# Altium schematic sheet isoSPI_Interface.SchDoc
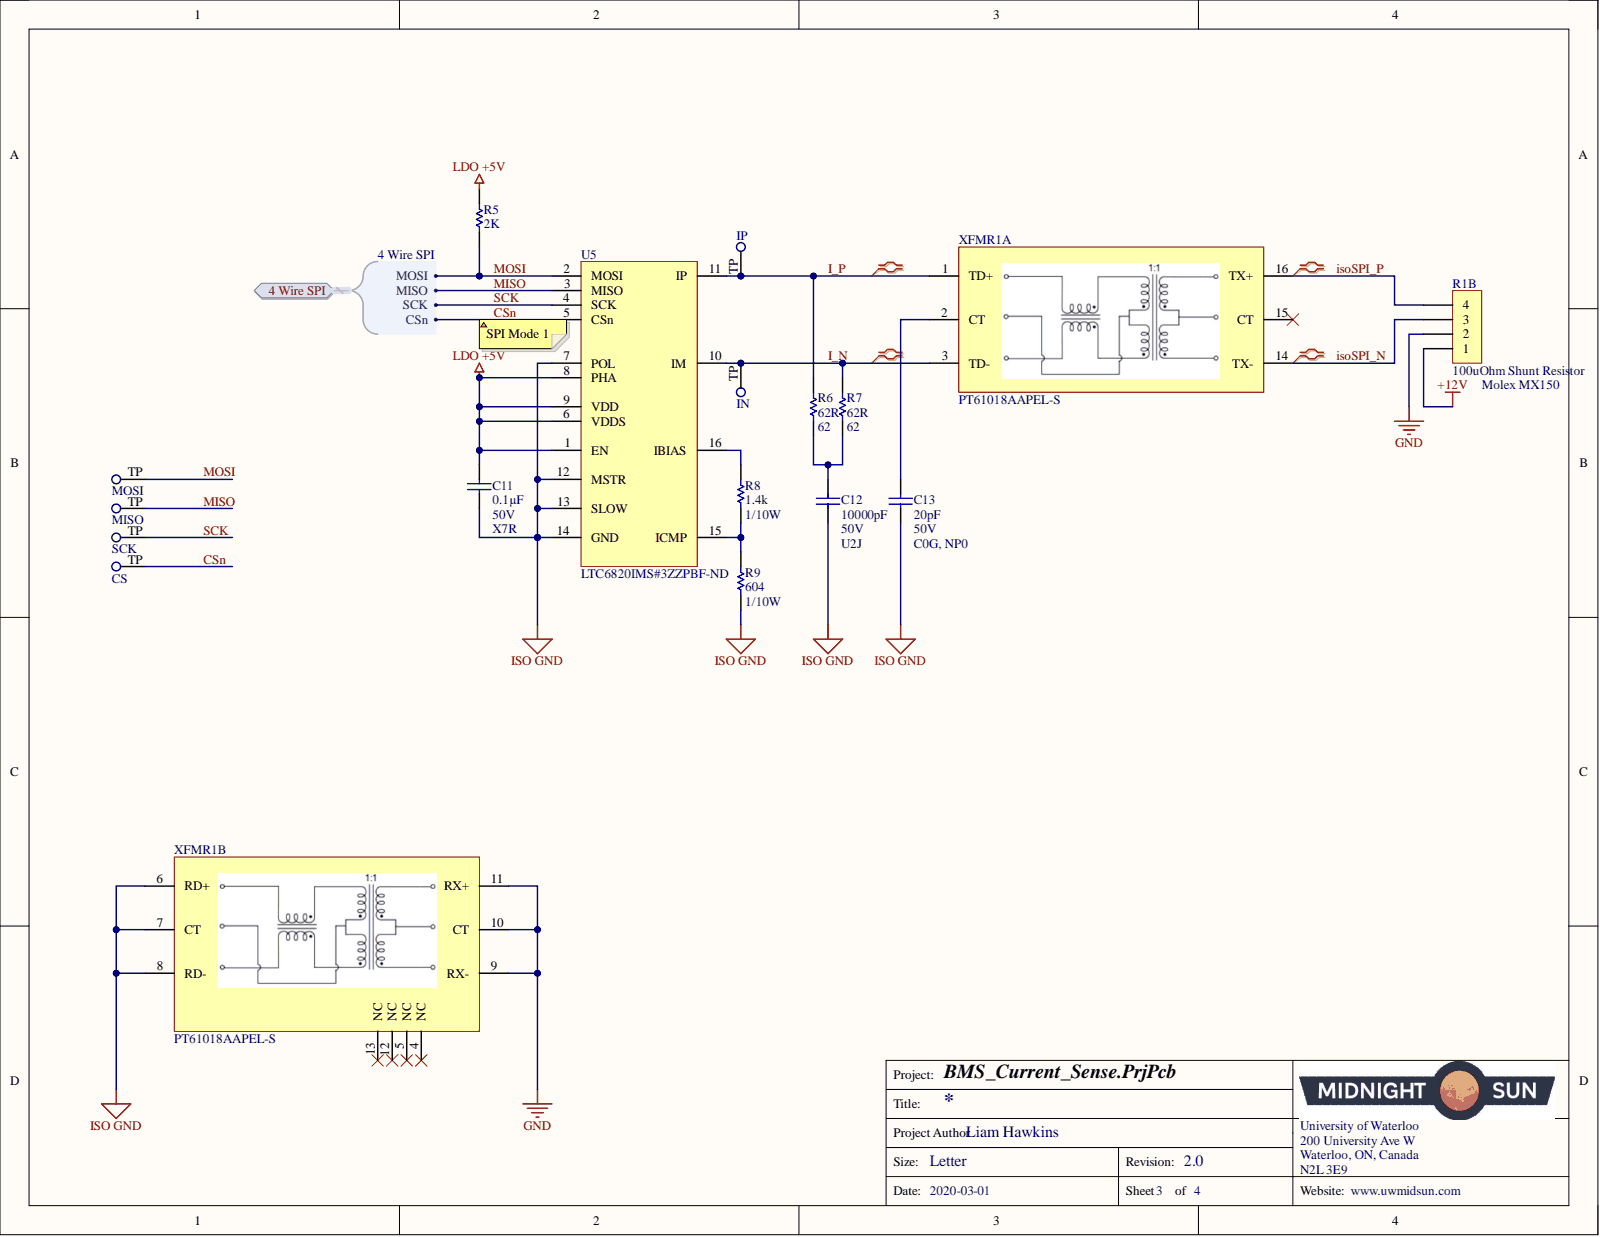
[diagram p0: isoSPI_Interface.SchDoc]

## Parts
C11  Capacitance: 0.1µF  Temperature Coefficient: X7R  Voltage: 50V  [CAP, 0603]  (hidden comment: CAP CER 0.1UF 50V 10% X7R 0603)
C12  Capacitance: 10000pF  Temperature Coefficient: U2J  Voltage: 50V  [CAP, 0603]  (hidden comment: CAP CER 10nF 50V 5% X7R 0603)
C13  Capacitance: 20pF  Voltage: 50V  [CAP, 0603]  (hidden comment: CAP CER 20PF 50V ±5% C0G/NP0 0603)
CS  Test Point  [Test Point]  (value not drawn)  (no BOM)
IN  Test Point  [Test Point]  (value not drawn)  (no BOM)
IP  Test Point  [Test Point]  (value not drawn)  (no BOM)
MISO  Test Point  [Test Point]  (value not drawn)  (no BOM)
MOSI  Test Point  [Test Point]  (value not drawn)  (no BOM)
R1  100uOhm Shunt Resistor  [RES SHUNT 100UOHM 60MM]  (part B)
R5  2K  [RES, 0603]
R6  62R  value 62  [RES, 0603]
R7  62R  value 62  [RES, 0603]
R8  Power: 1/10W  Resistance: 1.4k  [RES, 0603]  (hidden comment: RES 1.4k OHM 1% 1/10W 0603)
R9  Power: 1/10W  [RES, 0603]  (hidden comment: RES 604 OHM 1% 1/10W 0603)
SCK  Test Point  [Test Point]  (value not drawn)  (no BOM)
U5  Supplier Part Number 1: LTC6820IMS#3ZZPBF-ND  [IC isoSPI Interface LTC6820 MSOP]  (hidden comment: IC ISOSPI COMM INTERFACE LTC6820IMS#3ZZPBF)
XFMR1  MFG_PN: PT61018AAPEL-S  [IC, PULSE XFMR 1CT:1CT 350UH SMD]  (hidden comment: IC PULSE XFMR 1CT:1CT 350UH SMD)  (parts A,B)

## Ports
4 Wire SPI, 4 Wire SPI.CSn, 4 Wire SPI.MISO, 4 Wire SPI.MOSI, 4 Wire SPI.SCK

## Nets
+12V: R1.1
GND: R1.2 XFMR1.9(RX-) XFMR1.10(CT) XFMR1.11(RX+)
ISO GND: C11.2 C12.2 C13.2 R9.2 U5.7(POL) U5.12(MSTR) U5.13(SLOW) U5.14(GND) XFMR1.6(RD+) XFMR1.7(CT) XFMR1.8(RD-)
LDO +5V: C11.1 R5.1 U5.1(EN) U5.6(VDDS) U5.8(PHA) U5.9(VDD)
CSn: CS.TP U5.5(CSn) ; port 4 Wire SPI
I_3_N: IN.TP R7.1 U5.10(IM) XFMR1.3(TD-)  [also labelled I_N]
I_3_P: IP.TP R6.1 U5.11(IP) XFMR1.1(TD+)  [also labelled I_P]
isoSPI_3_N: R1.3 XFMR1.14(TX-)  [also labelled isoSPI_N]
isoSPI_3_P: R1.4 XFMR1.16(TX+)  [also labelled isoSPI_P]
MISO: MISO.TP U5.3(MISO) ; port 4 Wire SPI
MOSI: MOSI.TP R5.2 U5.2(MOSI) ; port 4 Wire SPI
SCK: SCK.TP U5.4(SCK) ; port 4 Wire SPI
NetC12_1: C12.1 R6.2 R7.2
NetC13_1: C13.1 XFMR1.2(CT)
NetR8_1: R8.1 U5.16(IBIAS)
NetR8_2: R8.2 R9.1 U5.15(ICMP)

Not connected: XFMR1 4(NC) 5(NC) 12(NC) 13(NC) 15(CT)

## Library data of the parts
C11 = CAP CER 0.1UF 50V 10% X7R 0603: 0.10µF ±10% 50V Ceramic Capacitor X7R 0603 (1608 Metric); Manufacturer 1: AVX Corporation; Manufacturer Part Number 1: 06035C104KAT2A; Component Kind: Standard; ComponentLink1Description: http://datasheets.avx.com/X7RDielectric.pdf; ComponentLink1URL: http://datasheets.avx.com/X7RDielectric.pdf; Height - Seated (Max): -; Lead Spacing: -; Lead Style: -; Mounting Type: Surface Mount, MLCC; Operating Temperature: -55°C ~ 125°C; Package / Case: 0603 (1608 Metric); Packaging: Cut Tape (CT); Part Status: Active; Ratings: -; Size / Dimension: 0.063" L x 0.032" W (1.60mm x 0.81mm); Supplier 1: Digi-Key; Supplier Part Number 1: 478-5052-1-ND; Thickness (Max): 0.035" (0.90mm); Tolerance: ±10%; Library Name: Schematic Diagrams.SchLib
C12 = CAP CER 10nF 50V 5% X7R 0603: 10000pF ±5% 50V Ceramic Capacitor U2J 0603 (1608 Metric); Manufacturer 1: KEMET; Manufacturer Part Number 1: C0603C103J5JAC7867; Component Kind: Standard; ComponentLink1Description: http://www.kemet.com/docfinder?Partnumber=C0603C103J5JAC7867; ComponentLink1URL: http://www.kemet.com/docfinder?Partnumber=C0603C103J5JAC7867; Failure Rate: -; Height - Seated (Max): -; Lead Spacing: -; Lead Style: -; Mounting Type: Surface Mount, MLCC; Operating Temperature: -55°C ~ 125°C; Package / Case: 0603 (1608 Metric); Packaging: Cut Tape (CT); Part Status: Active; Ratings: -; Size / Dimension: 0.063" L x 0.032" W (1.60mm x 0.80mm); Supplier 1: Digi-Key; Supplier Part Number 1: 399-13384-1-ND; Thickness (Max): 0.034" (0.87mm); Tolerance: ±5%; Library Name: Schematic Diagrams.SchLib
C13 = CAP CER 20PF 50V ±5% C0G/NP0 0603: 20pF ±5% 50V Ceramic Capacitor C0G, NP0 0603 (1608 Metric); Manufacturer 1: Murata Electronics North America; Manufacturer Part Number 1: GRM1885C1H200JA01D; Component Kind: Standard; ComponentLink1Description: http://www.murata.com/~/media/webrenewal/support/library/catalog/products/capacitor/mlcc/c02e.ashx; ComponentLink1URL: http://www.murata.com/~/media/webrenewal/support/library/catalog/products/capacitor/mlcc/c02e.ashx; Height - Seated (Max): -; Lead Spacing: -; Lead Style: -; Mounting Type: Surface Mount, MLCC; Operating Temperature: -55°C ~ 125°C; Package / Case: 0603 (1608 Metric); Packaging: Cut Tape (CT); Part Status: Active; Ratings: -; Size / Dimension: 0.063" L x 0.031" W (1.60mm x 0.80mm); Supplier 1: Digi-Key; Supplier Part Number 1: 490-1410-1-ND; Temperature Coefficient: C0G, NP0; Thickness (Max): 0.035" (0.90mm); Tolerance: ±5%; Library Name: Schematic Diagrams.SchLib
R1 = RES SHUNT 100UOHM 5% 36W 60MM: 100 µOhms ±5% 36W Shunt, Battery Specialized Resistor Metal Element ±175ppm/°C Shunt Fixture, 60mm Pitch; Manufacturer 1: Vishay Dale; Manufacturer Part Number 1: WSBM8518L1000JK; Applications: -; Category: Resistors; Component Kind: Standard; ComponentLink1Description: http://www.vishay.com/docs/31094/wsbm8518.pdf; ComponentLink1URL: http://www.vishay.com/docs/31094/wsbm8518.pdf; Composition: Metal Element; Description 1: RES SHUNT 100 UOHM 5% 36W; Mounting Type: Shunt Fixture, 60mm Pitch; Operating Temperature: -65°C ~ 170°C; Packaging: Bulk; Part Status: Active; Power (Watts): 36W; Pricing 1: 1=16.24, 10=14.764, 25=13.2876, 50=11.442, 100=10.5192, 250=9.9656, 500=9.5965, 1000=9.15359 (CAD); RoHS: RoHS Compliant; Stock 1: 0; Supplier 1: Digi-Key; Supplier Part Number 1: 541-1906-ND; Temperature Coefficient: ±175ppm/°C; Tolerance: ±5%; Type: Shunt, Battery; Library Name: Schematic Diagrams.SchLib
R5 = RES 2K OHM 1% 1/10W 0603: 2k Ohm ±1% 0.1W, 1/10W Chip Resistor 0603 (1608 Metric) Moisture Resistant Thick Film; Manufacturer: Yageo; Manufacturer Part Number: RC0603FR-072KL; Temperature Coefficient: ±100ppm/°C; Category: Resistors; Component Kind: Standard; ComponentLink1Description: http://www.yageo.com/documents/recent/PYu-RC_Group_51_RoHS_L_7.pdf; ComponentLink1URL: http://www.yageo.com/documents/recent/PYu-RC_Group_51_RoHS_L_7.pdf; Composition: Thick Film; Failure Rate: -; Features: Moisture Resistant; Height - Seated (Max): 0.022" (0.55mm); Operating Temperature: -55°C ~ 155°C; Package / Case: 0603 (1608 Metric); Packaging: Cut Tape (CT); Part Status: Active; Power (Watts): 0.1W, 1/10W; Pricing 1: 1=0.15, 10=0.023, 100=0.0093, 1000=0.00415, 2500=0.0036 (CAD); Resistance (Ohms): 2k; RoHS: RoHS Compliant; Size / Dimension: 0.063" L x 0.031" W (1.60mm x 0.80mm); Stock 1: 1750701; Supplier 1: Digi-Key; Supplier Device Package: 0603; Supplier Part Number 1: 311-2.00KHRCT-ND; Tolerance: ±1%; Library Name: Schematic Diagrams.SchLib
R6 R7 = RES 62 OHM 0.1% 1/10W 0603: 62 Ohms ±0.1% 0.1W, 1/10W Chip Resistor 0603 (1608 Metric) Automotive AEC-Q200 Thin Film; Manufacturer: Panasonic Electronic Components; Manufacturer Part Number: ERA-3AEB620V; Temperature Coefficient: ±25ppm/°C; Category: Resistors; Component Kind: Standard; ComponentLink1Description: https://industrial.panasonic.com/cdbs/www-data/pdf/RDM0000/AOA0000C307.pdf; ComponentLink1URL: https://industrial.panasonic.com/cdbs/www-data/pdf/RDM0000/AOA0000C307.pdf; Composition: Thin Film; Failure Rate: -; Features: Automotive AEC-Q200; Height - Seated (Max): 0.022" (0.55mm); Operating Temperature: -55°C ~ 155°C; Package / Case: 0603 (1608 Metric); Packaging: Cut Tape (CT); Part Status: Active; Power (Watts): 0.1W, 1/10W; Pricing 1: 1=0.52, 10=0.447, 100=0.1748, 1000=0.07317, 2500=0.06708 (CAD); RoHS: RoHS Compliant; Size / Dimension: 0.063" L x 0.032" W (1.60mm x 0.80mm); Stock 1: 10320; Supplier 1: Digi-Key; Supplier Device Package: 0603; Supplier Part Number 1: P62DBCT-ND; Tolerance: ±0.1%; Library Name: Schematic Diagrams.SchLib
R8 = RES 1.4k OHM 1% 1/10W 0603: RES SMD 1.4K OHM 1% 1/10W 0603; Manufacturer 1: Yageo; Manufacturer Part Number 1: RC0603FR-071K4L; Temperature Coefficient: ±100ppm/°C; Component Kind: Standard; ComponentLink1Description: http://www.yageo.com/documents/recent/PYu-RC_Group_51_RoHS_L_7.pdf; ComponentLink1URL: http://www.yageo.com/documents/recent/PYu-RC_Group_51_RoHS_L_7.pdf; Composition: Thick Film; Failure Rate: -; Height - Seated (Max): 0.022" (0.55mm); Operating Temperature: -55°C ~ 155°C; Package / Case: 0603 (1608 Metric); Packaging: Cut Tape (CT); Part Status: Active; Size / Dimension: 0.063" L x 0.031" W (1.60mm x 0.80mm); Supplier 1: Digi-Key; Supplier Device Package: 0603; Supplier Part Number 1: 311-1.40KHRCT-ND; Tolerance: ±1%; Library Name: Schematic Diagrams.SchLib
R9 = RES 604 OHM 1% 1/10W 0603: RES SMD 604 OHM 1% 1/10W 0603; Manufacturer 1: Yageo; Manufacturer Part Number 1: RC0603FR-07604RL; Resistance: 604; Temperature Coefficient: ±100ppm/°C; Component Kind: Standard; ComponentLink1Description: http://www.yageo.com/documents/recent/PYu-RC_Group_51_RoHS_L_7.pdf; ComponentLink1URL: http://www.yageo.com/documents/recent/PYu-RC_Group_51_RoHS_L_7.pdf; Composition: Thick Film; Failure Rate: -; Height - Seated (Max): 0.022" (0.55mm); Operating Temperature: -55°C ~ 155°C; Package / Case: 0603 (1608 Metric); Packaging: Cut Tape (CT); Part Status: Active; Size / Dimension: 0.063" L x 0.031" W (1.60mm x 0.80mm); Supplier 1: Digi-Key; Supplier Device Package: 0603; Supplier Part Number 1: 311-604HRCT-ND; Tolerance: ±1%; Library Name: Schematic Diagrams.SchLib
U5 = IC ISOSPI COMM INTERFACE LTC6820IMS#3ZZPBF: Isolated Communications Interface Interface 16-MSOP; Manufacturer: Linear Technology; Interface: SPI; Voltage - Supply: 2.7 V ~ 5.5 V; Applications: Isolated Communications Interface; Category: Integrated Circuits (ICs); Component Kind: Standard; ComponentLink1Description: http://www.linear.com/docs/42317; ComponentLink1URL: http://www.linear.com/docs/42317; Manufacturer Part Number: LTC6820IMS#3ZZPBF; Mounting Type: Surface Mount; Package / Case: 16-TFSOP (0.118", 3.00mm Width); Packaging: Tube; Part Status: Active; RoHS: RoHS3 Compliant; Supplier 1: Digi-Key; Supplier Device Package: 16-MSOP; Library Name: Schematic Diagrams.SchLib
XFMR1 = IC PULSE XFMR 1CT:1CT 350UH SMD: PULSE XFMR 1CT:1CT 350UH SMD; Manufacturer: Bourns Inc.; Isolation Voltage: 1.5kVrms; Component Kind: Standard; Inductance: 350uH; Supplier: Digikey; Supplier 1: Digi-Key; Supplier Part Number 1: PT61018AAPEL-SCT-ND; Supplier_PN: PT61018AAPEL-SCT-ND; Library Name: Schematic Diagrams.SchLib
CS IN IP MISO MOSI SCK = Test Point: Library Name: Schematic Diagrams.SchLib
